# Altium schematic sheet drvinput4.SchDoc(drvinput4)
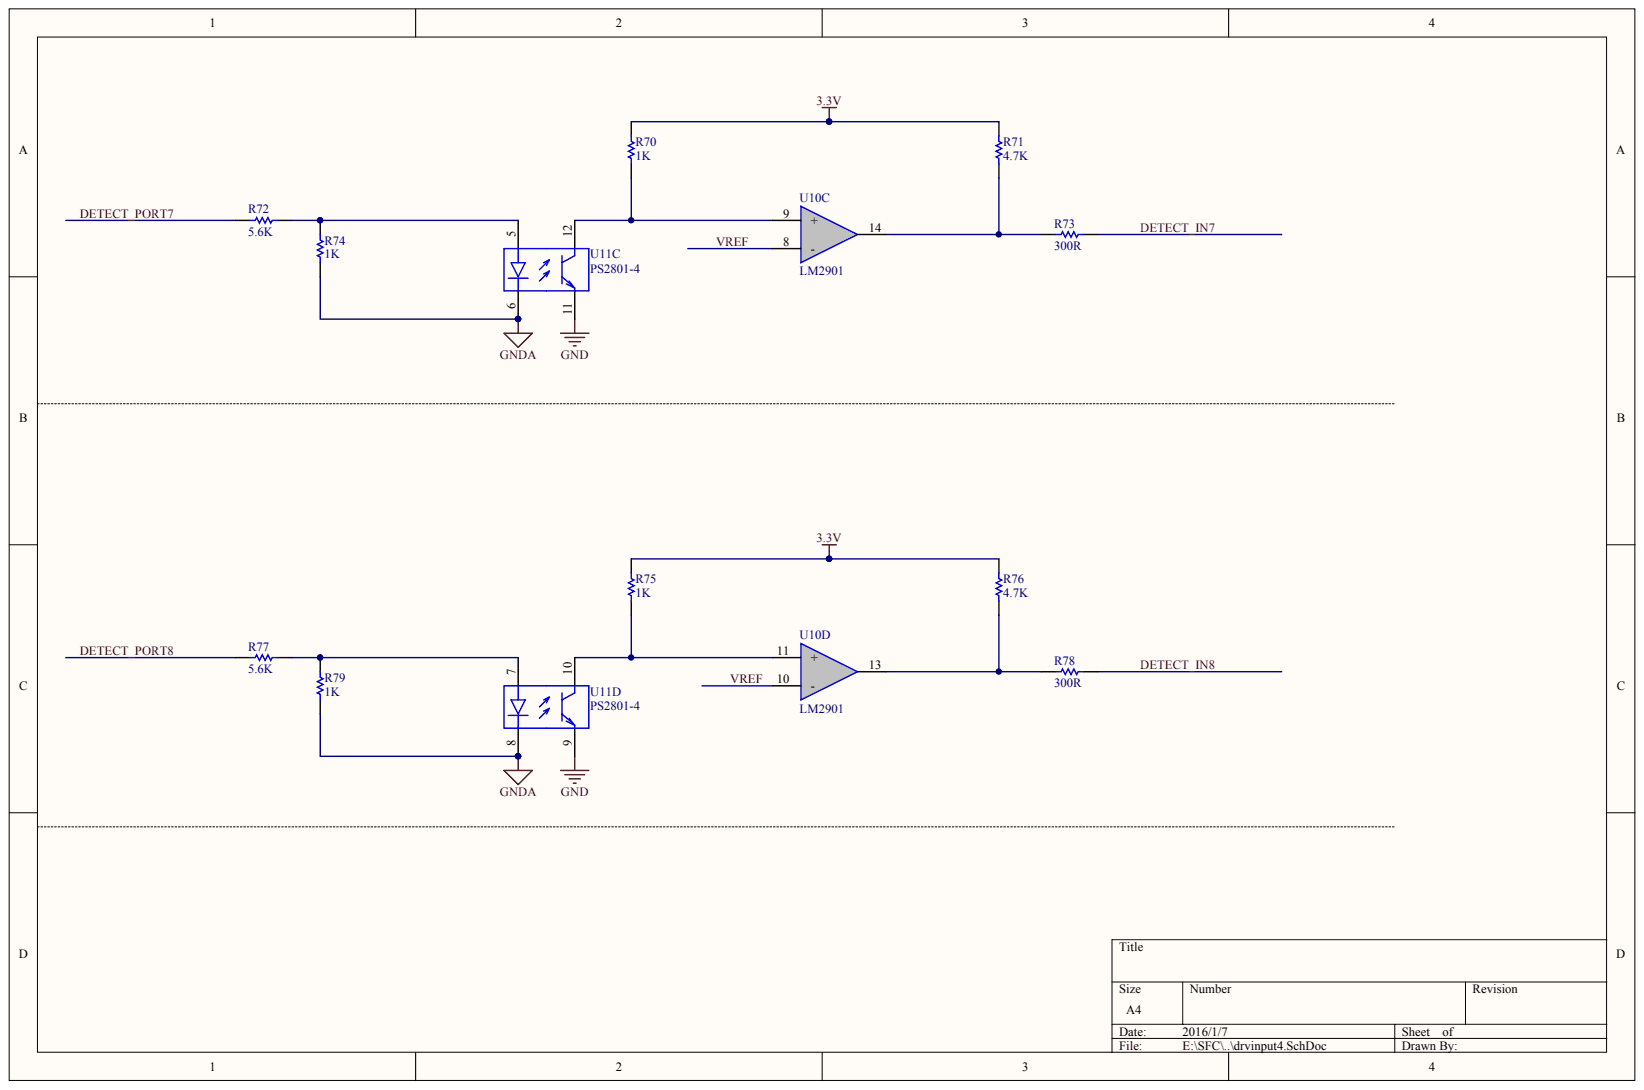
[diagram p0: drvinput4.SchDoc]

## Parts
R70  1K
R71  4.7K
R72  5.6K
R73  300R
R74  1K
R75  1K
R76  4.7K
R77  5.6K
R78  300R
R79  1K
U10  LM2901  (parts C,D)
U11  PS2801-4  (parts C,D)

## Nets
3.3V: R70.1 R71.2 R75.1 R76.2
GND: U11.9 U11.11
GNDA: R74.1 R79.1 U11.6 U11.8
DETECT_IN7: R73.1
DETECT_IN8: R78.2
DETECT_PORT7: R72.2
DETECT_PORT8: R77.2
VREF: U10.8(-) U10.10(-)
NetR70_2: R70.2 U10.9(+) U11.12
NetR71_1: R71.1 R73.2 U10.14
NetR72_1: R72.1 R74.2 U11.5
NetR75_2: R75.2 U10.11(+) U11.10
NetR76_1: R76.1 R78.1 U10.13
NetR77_1: R77.1 R79.2 U11.7

## Library data of the parts
R70-R77 R79 = (no symbol name): Value: 10K; Published: 8-Jun-2000; LatestRevisionDate: 17-Jul-2002; LatestRevisionNote: Re-released for DXP Platform.; PackageReference: AXIAL-0.3; Publisher: Altium Limited
R78 = (no symbol name): Value: 470; Published: 8-Jun-2000; LatestRevisionDate: 17-Jul-2002; LatestRevisionNote: Re-released for DXP Platform.; PackageReference: AXIAL-0.3; Publisher: Altium Limited
U10 U11 = (no symbol name)
  U11: Published: 28-Feb-2003; LatestRevisionDate: 13-Apr-2006; LatestRevisionNote: IPC-7351 Footprint Added.; DatasheetVersion: Jan-2002, Rev. 7; PackageVersion: rev7, Jan-2002; PackageReference: 751-07; PackageDescription: 8-Pin Plastic Small Outline Integrated Circuit 1.27 mm Pitch; Code_JEDEC: MS-012-AA; Code_IPC: SOIC127P600-8; ComponentLink1Description: Manufacturer Link; ComponentLink1URL: http://www.onsemi.com/; ComponentLink2Description: Datasheet; ComponentLink2URL: http://www.onsemi.com/pub/Collateral/LM358-D.PDF; Publisher: Altium Limited
